# Altium schematic sheet USB_Connectors.SchDoc(USB_Connectors)
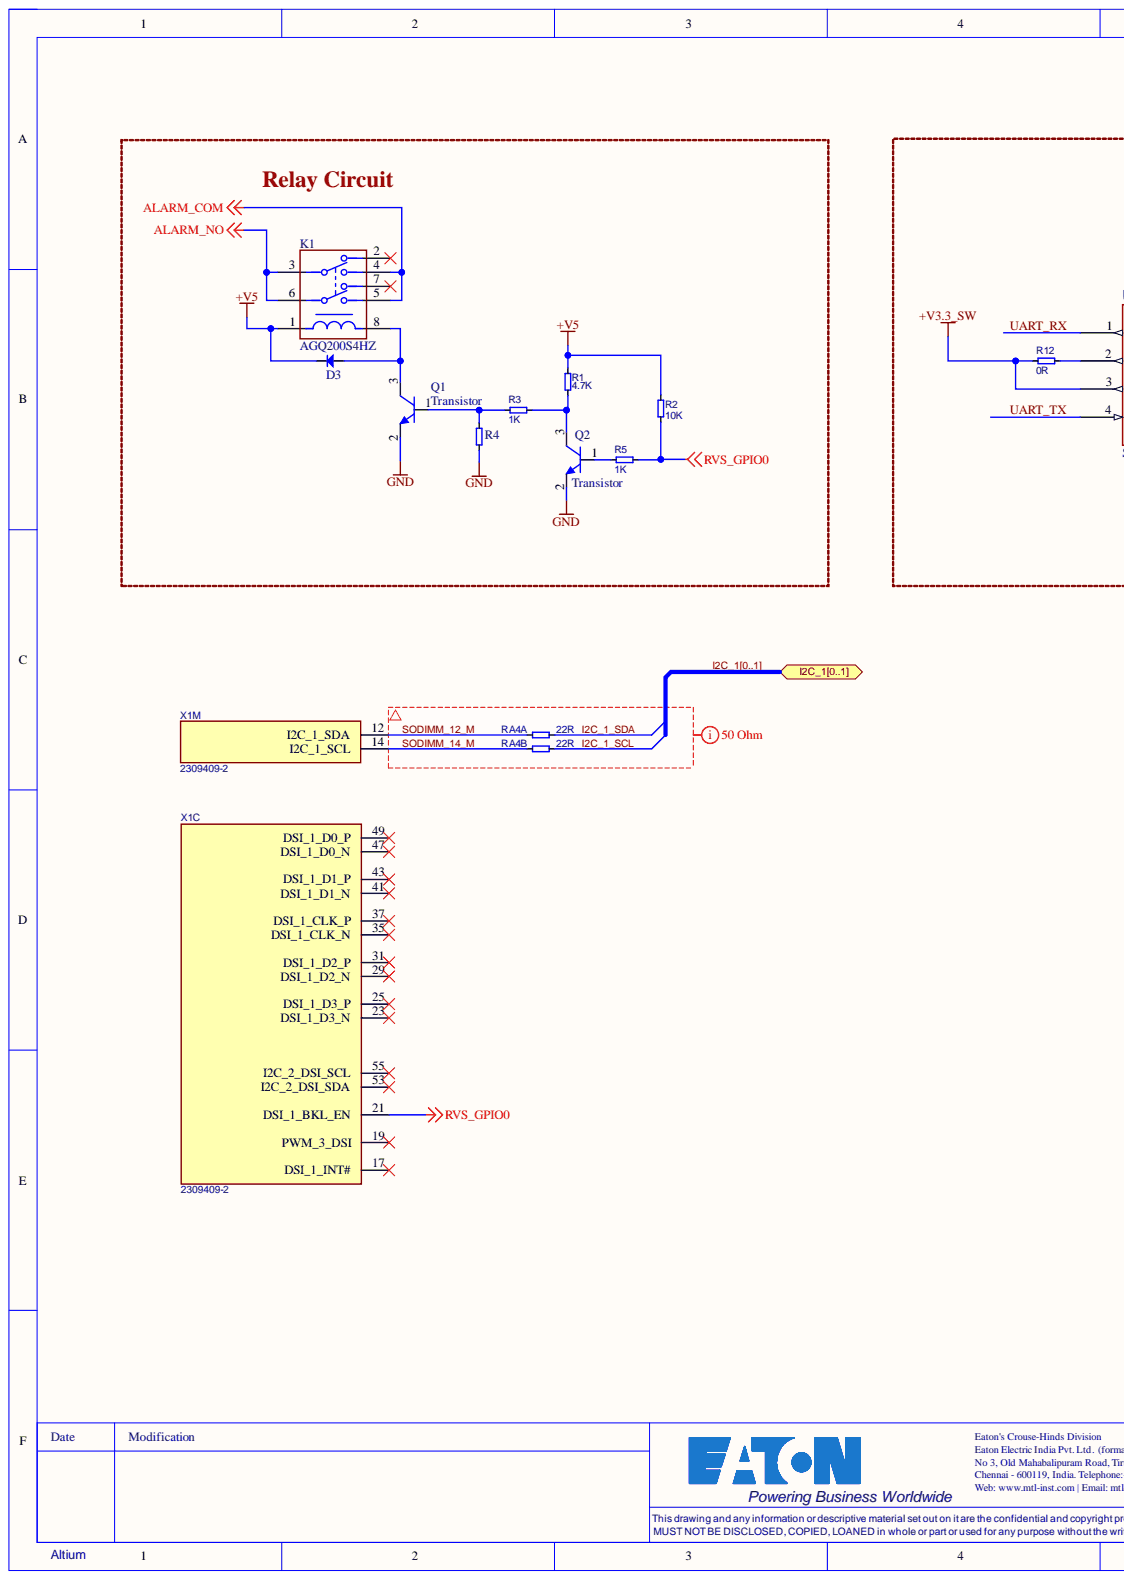
[diagram p0: USB_Connectors.SchDoc, left]
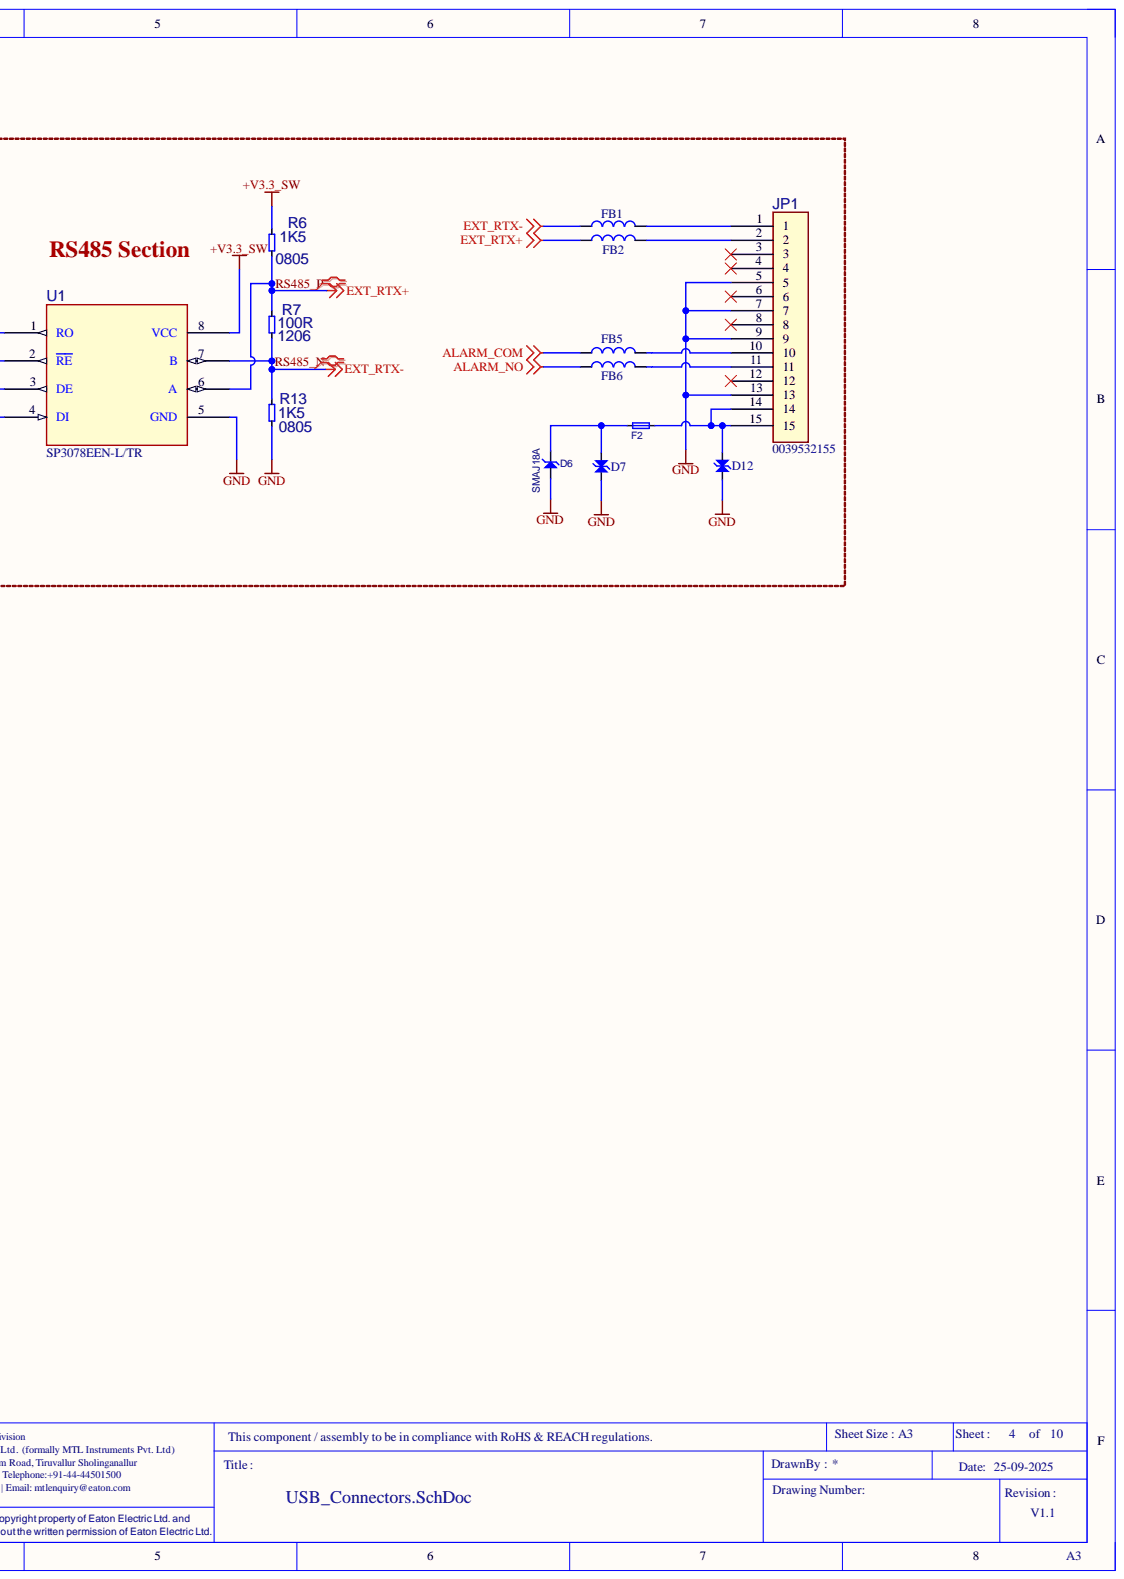
[diagram p1: USB_Connectors.SchDoc, right]

## Parts
D3  DIODE STANDARD 80V 100MA UMD2  [DIODE_SOT-323F-2]  (value not drawn)
D6  Manufacturer Part No.: SMAJ18A  (hidden comment: TVS DIODE 18VWM 29.2VC DO214AC)
D7  TVS_Diode  (value not drawn)
D12  TVS_Diode  (value not drawn)
F2  (no value)  [T_BelFuse-0685T8000-01]
FB1  Ferrite Bead  (value not drawn)
FB2  Ferrite Bead  (value not drawn)
FB5  Ferrite Bead  (value not drawn)
FB6  Ferrite Bead  (value not drawn)
JP1  Manufacturer Part No.: 0039532155  [B12BPHSM4TBLFSN]  (hidden comment: CONN FFC VERT 15POS 1.25MM PCB)
K1  AGQ200S4HZ  [FP-AGQ200S4HZ-MFG]
Q1  Transistor
Q2  Transistor
R1  4.7K  [RESC1005X40N]
R2  10K  [RESC1005X40N]
R3  1K  [RESC1005X40N]
R4  Res  (value not drawn)
R5  1K  [RESC1005X40N]
R6  Part Type: 0805  PART_NAME: 1K5  [R0805]  (hidden comment: RES 1.5K OHM 5% 1/4W 0805)
R7  Part Type: 1206  PART_NAME: 100R  [R1206]  (hidden comment: RES1206)
R12  0R  [RESC1005X40N]
R13  Part Type: 0805  PART_NAME: 1K5  [R0805]  (hidden comment: RES 1.5K OHM 5% 1/4W 0805)
RA4  22R  [T_Resistor_Array_0402_X4]  (parts A,B)
U1  IC TRANSCEIVER HALF 1/1 8SOIC  (value not drawn)
X1  2309409-2  [CON-TE-2309409-2]  (parts C,M)

## Ports
I2C_1[0..1], I2C_10, I2C_11

## Nets
+V3.3_SW: R6.1 R12.1 U1.3(DE) U1.8(VCC)
+V5: D3.C K1.1 R1.2 R2.2
ALARM_COM: FB5.1 K1.4 K1.5
ALARM_NO: FB6.1 K1.3 K1.6
GND: D6.A D7.1 D12.1 JP1.5 JP1.7 JP1.9 JP1.13 Q1.2 Q2.2 R4.1 R13.2 U1.5(GND)
RVS_GPIO0: R2.1 R5.2 X1.21(DSI_1_BKL_EN)
I2C_1_SCL: RA4.6
I2C_1_SDA: RA4.5
RS485_N: FB1.1 R7.1 R13.1 U1.7(B)
RS485_P: FB2.1 R6.2 R7.2 U1.6(A)
SODIMM_12_M: RA4.1 X1.12(I2C_1_SDA)
SODIMM_14_M: RA4.2 X1.14(I2C_1_SCL)
UART_RX: U1.1(RO)
UART_TX: U1.4(DI)
NetD3_A: D3.A K1.8 Q1.3
NetD6_C: D6.C D7.2 F2.1
NetD12_2: D12.2 F2.2 JP1.14 JP1.15
NetFB1_2: FB1.2 JP1.1
NetFB2_2: FB2.2 JP1.2
NetFB5_2: FB5.2 JP1.10
NetFB6_2: FB6.2 JP1.11
NetQ1_1: Q1.1 R3.1 R4.2
NetQ2_1: Q2.1 R5.1
NetQ2_3: Q2.3 R1.1 R3.2
NetR12_2: R12.2 U1.2(RE)
I2C_10: ; port I2C_1[0..1]  [also labelled I2C_1]
I2C_11: ; port I2C_1[0..1]  [also labelled I2C_1]

Not connected: JP1 3 4 6 8 12; K1 2 7; X1 17(DSI_1_INT#) 19(PWM_3_DSI) 23(DSI_1_D3_N) 25(DSI_1_D3_P) 29(DSI_1_D2_N) 31(DSI_1_D2_P) 35(DSI_1_CLK_N) 37(DSI_1_CLK_P) 41(DSI_1_D1_N) 43(DSI_1_D1_P) 47(DSI_1_D0_N) 49(DSI_1_D0_P) 53(I2C_2_DSI_SDA) 55(I2C_2_DSI_SCL)

## Library data of the parts
D3 = D-Diode-1SS355TE-17: Diode 80 V 100mA Surface Mount UMD2; Manufacturer Name: ROHM Semiconductor; Component Kind: Standard; Manufacturer Part No.: 1SS355TE-17; Library Name: Mallow.SchLib
D6 = D-LittleFuse-SMAJ18A: 29.2V Clamp 13.7A Ipp Tvs Diode Surface Mount DO-214AC (SMA); Manufacturer Name: LittelFuse Inc.; Component Kind: Standard; Library Name: Mallow.SchLib
F2 = Fuse: Fast Fuse, Rated 8A, 63V(DC), 1206 (3216 Metric); Component Kind: Standard; Library Name: SoM_Camera_Encoder.SCHLIB
JP1 = CON-15Pos-0039532155: 15 Position FFC, FPC Connector Contacts, Vertical - 1 Sided 0.049" (1.25mm) Through Hole; Manufacturer Name: JST Sales America Inc.; Component Kind: Standard; Library Name: Mallow.SchLib
K1 = Relay: RELAY TELECOM DPDT 2A 4.5VDC; Manufacturer Name: Panasonic; Coil Current: 31mA; Coil Power: 140mW; Coil Resistance: 145Ω; Coil Type: Non-Latching; Coil Voltage: 4.5V; Number Of Contacts: 2; Switching Power: 60W; Ambient Temperature Range High: +85°C; Ambient Temperature Range Low: -40°C; Automotive: No; Category: Relay; Component Kind: Standard; ComponentLink2Description: Datasheet; ComponentLink2URL: https://www3.panasonic.biz/ac/e_download/control/relay/signal/catalog/mech_eng_gq.pdf?f_cd=302252&via=ok; Device Class L1: Electromechanical; Device Class L2: Relays; Device Class L3: Signal Relays; Digikey Description: RELAY TELECOM DPDT 2A 4.5VDC; Height: 5.4mm; Lead Free: Yes; Library Name: SoM_Camera_Encoder.SCHLIB; Manufacturer Part No.: AGQ200S4HZ; Mouser Description: Low Signal Relays - PCB 2 Form C, 4.5VDC 30VDC SMD 4.5V; Octopart: https://octopart.com/agq200s4hz-panasonic-690173; Package: AGQ200S4HZ; RoHS: TRUE; Switching Current: 2A; Temperature: -40°C to +85°C; Temperature Range High: +85°C; Temperature Range Low: -40°C; Throw Configuration: DPDT
R1 = R-4.7K-63mW-5%-0402: Resistor 4.7 Kohm 63mW 5% 0402; Component Kind: Standard; Rating: 63mW; Tolerance: 5%; Value: 4.7K; Library Name: Mallow.SchLib
R2 = R-10K-63mW-5%-0402: Resistor 10 Kohm 63mW 5% 0402; Manufacturer Name: YAGEO; Manufacturer Part No.: RC0402JR-0710KL; Component Kind: Standard; Library Name: SoM_Camera_Encoder.SCHLIB; Rating: 63mW; Tolerance: 5%; Value: 10K
R3 R5 = R-1K-63mW-5%-0402: Resistor 1 Kohm 63mW 5% 0402; Component Kind: Standard; Rating: 63mW; Tolerance: 5%; Value: 1K; Library Name: Mallow.SchLib
R6 R13 = Res_1K5: 1.5 kOhms ±5% 0.25W, 1/4W Chip Resistor 0805 (2012 Metric) Automotive AEC-Q200 Thick Film; Manufacturer: KOA Speer Electronics, Inc.; MPN: RK73B2ATTD152J; ALLOWED_MOUNT_SIDE: BOTH; ID: 2483; Library: Con-Caps-Res-Crys-Dio-Fil; PDM Status: Released; Category: Resistors; Component Kind: Standard; Height: 0.71mm; Manuf 1 RoHS Compliant: True; Manuf 2 RoHS Compliant: True; Manuf 3 RoHS Compliant: False; Manuf 4 RoHS Compliant: True; Manuf 5 RoHS Compliant: True; Manuf 6 RoHS Compliant: False; Manuf 7 RoHS Compliant: False; Rev: 37; SMD: Yes; Status: Released; Title: RES 1.5K OHM 5% 1/4W 0805; TYPE: RESISTOR; Value: 1.5K Ohm; Library Name: Mallow.SchLib
R7 = RC01-100R: RES 100 OHM 1% 1/4W 1206; Manufacture 2: PHYCOMP-1; Manufacture 4: VISHAY-1; Manufacturer: Stackpole Electronics Inc; Manufactures Part No 2: 2322724(6, 7 or 8)1001L; Manufactures Part No 4: CRCW1206100RFK(EA, EB or EC); Manufactures Part No 5: D25/CRCW1206 100 100R 1% (ET1, ET5 or ET6) e3; Manufactures Part No 8: WCR1206-100R FI; Manufactures Part No 9: RK73H2BT(TD or TDD)1000F; MPN: RMCF1206FG100R; Part Label: 1206 1/4W 1% 100ppm RESISTOR; ALLOWED_MOUNT_SIDE: BOTH; ID: 2483; Library: Con-Caps-Res-Crys-Dio-Fil; PCB Footprint: R1206:R1206-ALTREFLOW:R1206W:R1206-ALTWAVE:R1206_S; PDM Status: Released; Prefix: ML; SAP No: MLRC01-100R; Title: 1206 1/4W 1% RESISTOR CHIP; Value: 100Ohm; Category: Resistors; Component Kind: Standard; Height: 0.71mm; Manuf 1 RoHS Compliant: True; Manuf 2 RoHS Compliant: True; Manuf 3 RoHS Compliant: False; Manuf 4 RoHS Compliant: True; Manuf 5 RoHS Compliant: True; Manuf 6 RoHS Compliant: False; Manuf 7 RoHS Compliant: False; Manuf 8 RoHS Compliant: True; Manuf 9 RoHS Compliant: True; Manuf 10 RoHS Compliant: False; Manuf 11 RoHS Compliant: False; Manuf 12 RoHS Compliant: False; Manufacture 5: VISHAY-1; PartNo: RC01-100R; PartNoFromVariants: RC01-100R; Rev: 37; SMD: Yes; Status: Released; TYPE: RESISTOR; Library Name: Mallow.SchLib
R12 = R-0R-63mW-1%-0402: Resistor 0 ohm 63mW 1% 0402; Manufacturer Name: Thin Film Technology Corp.; Manufacturer Part No.: TFAS0402WJUMPF-T10; Component Kind: Standard; Library Name: SoM_Camera_Encoder.SCHLIB; Rating: 63mW; Tolerance: 1%; Value: 0R
RA4 = RA-22R-63mW-5%-0804-div: Resistor Array 22 R 63mW 5% 0804, Concave type; Component Kind: Standard; Library Name: SoM_Camera_Encoder.SCHLIB; Power Dissipation: 63mW; Tolerance: 5%; Value: 22R
U1 = SP3078EEN-L/TR: 1/1 Transceiver Half RS422, RS485 8-SOIC; Manufacturer: MaxLinear, Inc.; Component Kind: Standard; MPN: SP3078EEN-L/TR; Package: 8-SOIC; Library Name: Mallow.SchLib
X1 = CON-TE-2309409-2: DDR4 SODIMM Socket, 260 pins, 5.2mm(H), 0.5mm Pitch; Manufacturer Name: TE Connectivity; Component Kind: Standard; Library Name: SoM_Camera_Encoder.SCHLIB; Manufacturer Part No.: 2309409-2; Package: DDR4-SODIMM-260; Temperature Range: -40C to +125C
D7 D12 = TVS_Diode: Component Kind: Standard; Library Name: Mallow.SchLib
FB1 FB2 FB5 FB6 = Ferrite Bead: Component Kind: Standard; Library Name: Mallow.SchLib
Q1 Q2 = Transistor: Component Kind: Standard; Library Name: Mallow.SchLib
R4 = Res: Component Kind: Standard; Library Name: Mallow.SchLib
